# Altium schematic sheet Powersupply.SchDoc(Powersupply)
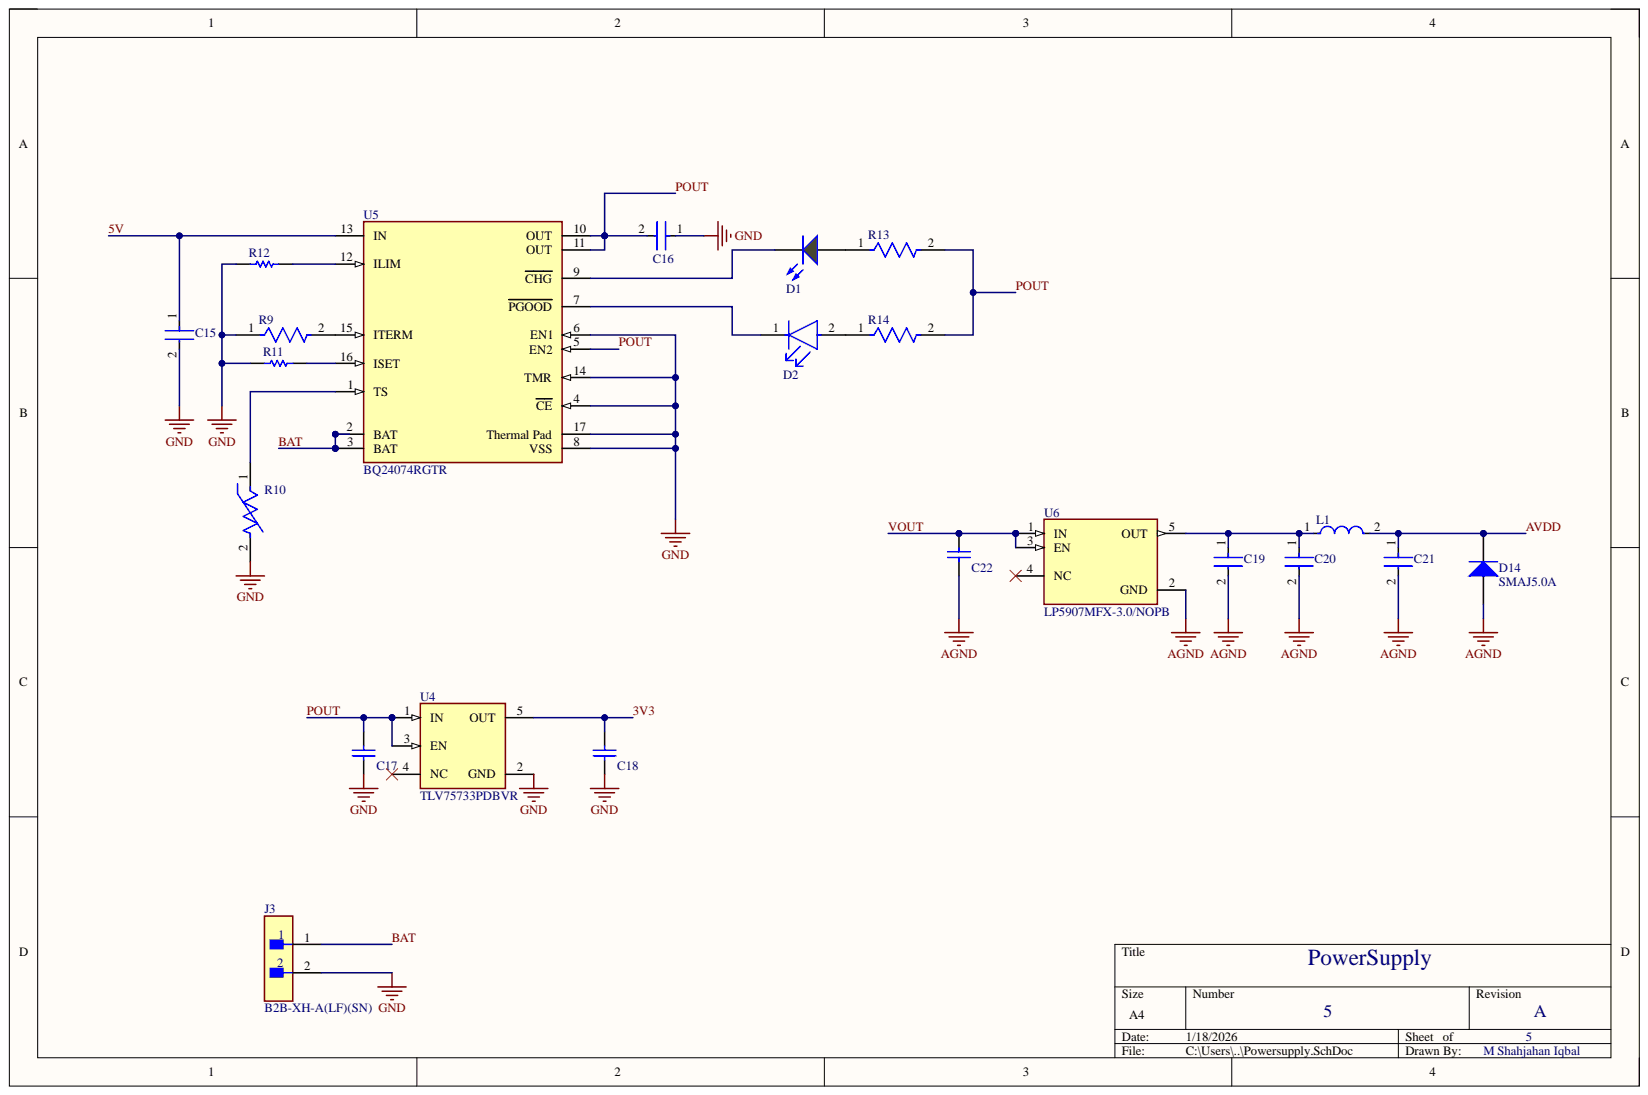
[diagram p0: Powersupply.SchDoc]

## Parts
C15  CL10B105KP8NNNC  [FP-CL10-IPC_C]  (value not drawn)
C16  CL10A475KP8NNNC  [FP-CL10-IPC_C]  (value not drawn)
C17  GRM188R61A105KA61D  [CAPC1608X90X35LL10T15]  (value not drawn)
C18  GRM188R61A105KA61D  [CAPC1608X90X35LL10T15]  (value not drawn)
C19  CC0603KRX7R6BB225  [FP-CC0603-DA-MFG]  (value not drawn)
C20  GRT188R61A106KE13D  [FP-GRT188-0_15-IPC_C]  (value not drawn)
C21  GRT188R61A106KE13D  [FP-GRT188-0_15-IPC_C]  (value not drawn)
C22  GRM188R61A105KA61D  [CAPC1608X90X35LL10T15]  (value not drawn)
D1  APT1608SGC  [KING-APT1608SGC_V]  (value not drawn)
D2  APT1608SYCK  [FP-APT1608SYCK-MFG]  (value not drawn)
D14  SMAJ5.0A  [BOUR-SMA-2_V]
J3  B2B-XH-A(LF)(SN)  [FP-B2B-XH-A_LF_SN-MFG]
L1  LQM21PN3R3MG0D  [FP-LQM21PH_G0-MFG]  (value not drawn)
R9  CRCW06034K12FKEA  [FP-CRCW0603-e3-IPC_B]  (value not drawn)
R10  103AT-2  [FP-103AT-2-MFG]  (value not drawn)
R11  CRCW06031K13FKEA  [RESC1609X50X30ML10T20]  (value not drawn)
R12  CRCW06031K18FKEA  [RESC1609X50X30ML10T20]  (value not drawn)
R13  AF0603FR-071K5L  [FP-AF0603-IPC_B]  (value not drawn)
R14  AF0603FR-071K5L  [FP-AF0603-IPC_B]  (value not drawn)
U4  TLV75733PDBVR  [FP-DBV0005A-MFG]
U5  BQ24074RGTR  [FP-RGT0016C-IPC_B]
U6  LP5907MFX-3.0/NOPB  [SOT95P280X145-5N]

## Nets
AGND: C19.2 C20.2 C21.2 C22.2 D14.1 U6.2(GND)
GND: C15.2 C16.1 C17.2 C18.2 J3.2 R9.1 R10.2 R11.1 R12.1 U4.2(GND) U5.4(CE) U5.6(EN1) U5.8(VSS) U5.14(TMR) U5.17(Thermal_Pad)
3V3: C18.1 U4.5(OUT)
5V: C15.1 U5.13(IN)
AVDD: C21.1 D14.2 L1.2
BAT: J3.1 U5.2(BAT) U5.3(BAT)
POUT: C16.2 C17.1 R13.2 R14.2 U4.1(IN) U4.3(EN) U5.5(EN2) U5.10(OUT) U5.11(OUT)
VOUT: C22.1 U6.1(IN) U6.3(EN)
NetC19_1: C19.1 C20.1 L1.1 U6.5(OUT)
NetD1_1: D1.1 R13.1
NetD1_2: D1.2 U5.9(CHG)
NetD2_1: D2.1 U5.7(PGOOD)
NetD2_2: D2.2 R14.1
NetR9_2: R9.2 U5.15(ITERM)
NetR10_1: R10.1 U5.1(TS)
NetR11_2: R11.2 U5.16(ISET)
NetR12_2: R12.2 U5.12(ILIM)

Not connected: U4 4(NC); U6 4(NC)

## Library data of the parts
C15 = CMP-2000-06094-2: Cap Ceramic 1uF 10V X7R ±10% Pad SMD 0603 +125°C T/R; Capacitance: 1uF; Case Code (Imperial): 0603; Case Code (Metric): 1608; Case/Package: 0603; Component Kind: Standard; Contact Plating: Tin; Depth: 0.8mm; Dielectric: X7R; Height: 0.8mm; Lead Free: Lead Free; Length: 1.6mm; Library Name: Altium Content Vault; Max Operating Temperature: 125°C; Min Operating Temperature: -55°C; Mount: Surface Mount; Package Quantity: 1; Packaging: Tape and Reel; Radiation Hardening: No; Thickness: 0.035inch; Tolerance: 10%; Voltage Rating: 10V; Voltage Rating (DC): 10V; Width: 0.031inch
C16 = CMP-13271-003017-1: Cap Ceramic 4.7uF 10V X5R ±10% Pad SMD 0603 +85°C T/R; Capacitance: 4.7uF; Case Code (Imperial): 0603; Case Code (Metric): 1608; Case/Package: 0603; Component Kind: Standard; Contact Plating: Tin; Depth: 0.8mm; Dielectric: X5R; Height: 0.8mm; Length: 1.6mm; Library Name: Altium Content Vault; Max Operating Temperature: 85°C; Min Operating Temperature: -55°C; Mount: Surface Mount; Package Quantity: 1; Packaging: Tape and Reel; Radiation Hardening: No; Thickness: 0.035inch; Tolerance: 10%; Voltage Rating: 10V; Width: 0.031inch
C17 C18 C22 = CMP-2000-06336-1: Chip Capacitor, 1 uF, +/- 10%, 10 V, -55 to 85 degC, 0603 (1608 Metric), RoHS, Tape and Reel; Manufacturer: Murata; Brand: MurataElectronics; Capacitance: 1uF; Capacitance - nF: 1000nF; Case Height: 0.8mm; Case Length: 1.6mm; Dielectric: X5R; Temperature Coefficient / Code: +/-15%; Type: GeneralPurposeMLCC; Voltage Rating: 10V; Case Code - in: 0603; Case Code - mm: 1608; Case Width: 0.8mm; Component Kind: Standard; Datasheet URL: http://search.murata.co.jp/Ceramy/image/img/A01X/G101/ENG/GRM188R61A105KA61-01.pdf; Datasheet Version: 02/2016; Dimensions: 0.8mmWx1.6mmLx0.8mmH; Factory Pack Quantity: 4000; Library Name: Altium Content Vault; Manufacturer URL: http://www.murata.com/; Maximum Operating Temperature: +85C; Minimum Operating Temperature: -55C; Mounting Technology: SurfaceMount; Operating Temperature Range: -55Cto+85C; Package / Case: 0603(1608metric); Package Description: 2-Pin Surface Mount Device, Body 1.6 x 0.8 mm; Package Reference: 0603; Packaging: Reel; Product: GeneralTypeMLCCs; Product Category: MultilayerCeramicCapacitorsMLCC-SMD/SMT; RoHS: Details; Series: GRM; Termination Style: SMD/SMT; Tolerance: 10%; Voltage Rating DC: 10V
C19 = CMP-03422-000148-1: CAP CER 2.2UF 10V X7R 0603; Manufacturer: YAGEO; Material: Ceramic; Temperature Coefficient: 15%; Tolerance: 0.1; Automotive: No; Capacitance: 2.2 uF; Category: Cap; Component Kind: Standard; ComponentLink2Description: Datasheet; ComponentLink2URL: http://www.yageo.com/documents/recent/UPY-GPHC_X7R_6.3V-to-50V_18.pdf; Device Class L1: Passive Components; Device Class L2: Capacitors; Device Class L3: Ceramic Capacitors; Digikey Description: CAP CER 2.2UF 10V X7R 0603; Footprint Url: http://www.yageo.com/exep/pages/download/literatures/UPY-C_GEN_24.pdf; Height: 0.9mm; Lead Free: Yes; Library Name: Altium Content Vault; Manufacturer Part Number: CC0603KRX7R6BB225; Mouser Description: Multilayer Ceramic Capacitors MLCC - SMD/SMT 2.2uF 10V X7R 10%; Octopart: https://octopart.com/cc0603krx7r6bb225-yageo-20649696; Package: CC0603-DA; RoHS: TRUE; Temperature: -55°C to +125°C; Temperature Characteristic: X7R; Temperature Range High: +125°C; Temperature Range Low: -55°C; Value: 2.2 uF; Voltage: 10 V; Voltage Rating: 10 V
C20 C21 = CMP-06035-045859-1: Multi-Layer Ceramic Capacitor 10uF 10V X5R ±10% 0603 Paper T/R; Capacitance: 10uF; Case Code (Imperial): 0603; Case Code (Metric): 1608; Case/Package: 0603; Component Kind: Standard; Length: 0.063inch; Library Name: Altium Content Vault; Max Operating Temperature: 85°C; Min Operating Temperature: -55°C; Mount: Surface Mount; Packaging: Cut Tape; Ratings: AEC-Q200; Thickness: 0.035inch; Tolerance: 10%; Voltage Rating: 10V; Width: 0.031inch
D1 = CMP-2000-05789-1: Super Bright Green LED, 62.5 mW, 25 mA, -40 to 85 degC, 2-Pin SMD, RoHS, Tape and Reel; Manufacturer: Kingbright; 3D Model: APT1608x.sldprt    APT1608x.pro    APT1608x.igs    APT1608x.stp; Catalog Drawings: APT1608SeriesTop    APT1608SeriesSide; Current - Test: 20mA; Lens Style/Size: RectanglewithFlatTop,1.2mmx0.8mm; Lens Type: Clear; Millicandela Rating: 15mcd; Online Catalog: 0603RectanglewithFlatTopChipLEDs; Other Names: 754-1121-2; Viewing Angle: 120°; Voltage - Forward (Vf) (Typ): 2.2V; Wavelength - Dominant: 568nm; Wavelength - Peak: 565nm; Category: Optoelectronics; Color: Green; Component Kind: Standard; Datasheet URL: https://datasheet.ciiva.com/2113/apt1608sgc-2113808.pdf; Datasheet Version: Rev. 16B, 02/2012; Family: LEDIndication-Discrete; Height: 0.75mm; Library Name: Altium Content Vault; Manufacturer URL: http://www.kingbright.com/; Mounting Technology: SurfaceMount; Mounting Type: SurfaceMount; Package / Case: 0603(1608Metric); Package Description: 2-Pin Surface Mount Device, Body 0.8 x 1.6 mm; Package Reference: APT1608SGC; Packaging: Tape&Reel(TR); Size / Dimension: 1.60mmLx0.80mmW; Standard Package: 2,000
D2 = CMP-44529-000010-1: LED YELLOW CLEAR CHIP SMD; Manufacturer: Kingbright; Forward Current: 20mA; Forward Voltage: 2V; Led Orientation: Top View; Luminous Intensity: 150mcd; Max Junction Temp: +115°C; Peak Emmision Wavelength: 590nm; Power Dissipation: 75mW; Reverse Voltage: 5V; Viewing Angle: 120°; Automotive: No; Category: Diode; Colour: Yellow; Component Kind: Standard; ComponentLink2Description: Datasheet; ComponentLink2URL: http://www.kingbrightusa.com/images/catalog/SPEC/APT1608SYCK.pdf; Device Class L1: Optoelectronics; Device Class L2: LEDs; Device Class L3: unset; Digikey Description: LED YELLOW CLEAR CHIP SMD; Height: 0.85mm; Lead Free: Yes; Library Name: Altium Content Vault; Manufacturer Part Number: APT1608SYCK; Mouser Description: Standard LEDs - SMD YELLOW WATER CLEAR; Octopart: https://octopart.com/apt1608syck-kingbright-868958; Package: APT1608SYCK; RoHS: TRUE; Temperature: -40°C to +85°C; Temperature Range High: +85°C; Temperature Range Low: -40°C; Wavelength: 590nm
D14 = CMP-2000-07090-1: SMAJ Transient Voltage Suppressor Diode Series, 3.5 V, -55 to 150 degC, 2-Pin SMA, RoHS, Tape and Reel; Manufacturer: Bourns; Catalog Drawings: SMAJSeriesFootprintSMAJ,SMBJSeries; Current - Peak Pulse (10/1000µs): 43.5A; EDA / CAD Models: DownloadfromAcceleratedDesigns; Featured Product: SMAJ/SMBJ/SMCJ/SMLJDiscreteTVSDiodes; Online Catalog: SMAJSeries; Other Names: SMAJ5.0ABDKR; Power - Peak Pulse: 400W; Power Line Protection: No; Type: Zener; Unidirectional Channels: 1; Voltage - Breakdown (Min): 6.4V; Voltage - Clamping (Max) @ Ipp: 9.2V; Voltage - Reverse Standoff (Typ): 5V; Applications: GeneralPurpose; Bidirectional Channels: -; Capacitance @ Frequency: -; Category: CircuitProtection; Component Kind: Standard; Datasheet URL: https://datasheet.ciiva.com/25624/0900766b8112213b-25624680.pdf; Datasheet Version: 08/2012; Family: TVS-Diodes; Library Name: Altium Content Vault; Manufacturer URL: http://www.bourns.com/; Mounting Technology: SurfaceMount; Mounting Type: SurfaceMount; Operating Temperature: -55°C~150°C(TJ); Package / Case: DO-214AC,SMA; Package Description: 2-Pin Surface Mount Device, Body 4.315 x 2.605 mm; Package Reference: SMA-2; Packaging: Digi-Reel®; RoHS Information: SMAJSeriesMaterialDeclaration; Series: SMAJ; Standard Package: 1; Supplier Device Package: SMA(DO-214AC)
J3 = CMP-2000-05888-3: CONN HEADER VERT 2POS 2.5MM; Connector Type: Male Pin; Contact Resistance: 20mR; Current Rating: 3A; Insulation Resistance: 1GR; Max Voltage Rating (AC): 250V; Number of Contacts: 2; Wire/Cable Gauge: 22AWG; Color: Natural; Component Kind: Standard; Contact Material: Brass; Contact Plating: Tin; Contact Type: Male Pin; Depth: 5.75mm; Fastening Type: Detent; Housing Color: White; Housing Material: Polyamide; Lead Free: Lead Free; Lead Pitch: 2.5mm; Length: 7.4mm; Library Name: Altium Content Vault; Max Current Rating: 3A; Max Operating Temperature: 85°C; Max Voltage Rating (DC): 250V; Min Operating Temperature: -25°C; Mount: Through Hole; Number of Positions: 2; Number of Rows: 1; Orientation: Straight; Packaging: Bulk; Pitch: 2.5mm; REACH SVHC: No SVHC; Series: XH; Termination: Solder; Voltage Rating: 250V; Voltage Rating (AC): 250V
L1 = CMP-06042-017003-1: Multilayer Type Inductor for Power Lines, 0805 (2012), 3.3μH, 20%, 0.207Ω, 0.8A; Core Material: Ferrite; Current Rating: 800mA; DC Resistance (DCR): 207mR; Inductance: 3.3uH; Self Resonant Frequency: 30MHz; Shielding: Shielded; Test Frequency: 1MHz; Case/Package: 0805; Component Kind: Standard; Height - Seated (Max): 0.039inch; Length: 0.079inch; Library Name: Altium Content Vault; Max Operating Temperature: 125°C; Min Operating Temperature: -55°C; Mount: Surface Mount; Package Quantity: 4000; Packaging: Tape and Reel; RoHS Compliant: Yes; Tolerance: 20%; Width: 0.049inch
R9 = CMP-2000-03403-2: RES Thick Film, 4.12kΩ, 1%, 0.1W, 100ppm/°C, 0603; Max Power Dissipation: 100mW; Resistance: 4.12kR; Voltage Rating: 75V; Case Code (Imperial): 0603; Case Code (Metric): 1608; Case/Package: 0603; Component Kind: Standard; Composition: Thick Film; Contact Plating: Tin; Depth: 0.85mm; Features: Automotive AEC-Q200; Height: 0.45mm; Lead Free: Lead Free; Length: 1.55mm; Library Name: Altium Content Vault; Max Operating Temperature: 155°C; Military Standard: Not; Min Operating Temperature: -55°C; Mount: Surface Mount; Number of Pins: 2; Number of Terminations: 2; Package Quantity: 10; Packaging: Tape and Reel; Power Rating: 100mW; Radiation Hardening: No; Temperature Coefficient: 100ppm/°C; Termination: SMD/SMT; Tolerance: 1%; Voltage Rating (DC): 75V; Weight: 7.1E-05oz; Width: 0.85mm
R10 = CMP-2000-05824-2: Thermistor NTC 10KOhm 1% 2-Pin; Resistance: 10kR; Component Kind: Standard; Height: 2.4mm; Lead Pitch: 2.54mm; Length: 17mm; Library Name: Altium Content Vault; Max Operating Temperature: 110°C; Min Operating Temperature: -50°C; Number of Pins: 2; Packaging: Bulk; RoHS Compliant: Yes; Series: AT; Termination: Radial; Tolerance: 1%; Width: 3.7mm
R11 = CMP-2000-02979-1: Manufacturer: Vishay Dale; Component Kind: Standard; Library Name: Altium Content Vault; Part Number: CRCW06031K13FKEA
R12 = CMP-2000-02981-1: Manufacturer: Vishay Dale; Component Kind: Standard; Library Name: Altium Content Vault; Part Number: CRCW06031K18FKEA
R13 R14 = CMP-03412-043191-1: Anti-Sulfurated Chip Resistors Automotive Grade 1.5kΩ 1% 100ppm/°C 0603; Max Power Dissipation: 100mW; Resistance: 1.5kR; Case/Package: 0603; Component Kind: Standard; Features: Moisture Resistant; Height: 0.022inch; Length: 0.063inch; Library Name: Altium Content Vault; Max Operating Temperature: 155°C; Min Operating Temperature: -55°C; Number of Terminations: 2; Packaging: Tape and Reel; Resistor Type: Thick Film; RoHS Compliant: Yes; Series: AF; Temperature Coefficient: 100ppm/°C; Tolerance: 1%; Width: 0.031inch
U4 = CMP-04917-000028-1: IC REG LINEAR 3.3V 1A SOT23-5; Manufacturer: Texas Instruments; Accuracy Percentage: 1%; Dropout Voltage: 1350mV; Max Junction Temp: +125°C; Max Supply Voltage: 5.5V; Min Supply Voltage: 1.45V; Number Of Outputs: 1; Output Type: Fixed; Standoff Height: 0mm; Automotive: No; Category: IC; Component Kind: Standard; ComponentLink2Description: Datasheet; ComponentLink2URL: http://www.ti.com/lit/ds/symlink/tlv757p.pdf; Device Class L1: Integrated Circuits (ICs); Device Class L2: Power Management ICs; Device Class L3: Voltage Regulators - Linear; Digikey Description: IC REG LINEAR 3.3V 1A SOT23-5; Height: 1.45mm; Ipc Land Pattern Name: SOT95P280X145-5; Lead Free: Yes; Library Name: Altium Content Vault; Manufacturer Part Number: TLV75733PDBVR; Mouser Description: 1-A low-IQ small-size low-dropout (LDO) regulator 5-SOT-23 -40 to 125; Octopart: https://octopart.com/tlv75733pdbvr-texas+instruments-91437073; Output Current: 1A; Output Voltage: 3.3V; Package: DBV0005A; RoHS: TRUE; Temperature: -40°C to +125°C; Temperature Range High: +125°C; Temperature Range Low: -40°C
U5 = CMP-0321-00220-3: IC LI-ION CHARGE MGMT 16-QFN; Battery Chemistry: LITHIUM-ION; Battery Pack Voltage: 4.2V; Fault Protection: Over Voltage; Interface: USB; Max Charge Current: 1.5A; Max Supply Voltage: 10.2V; Min Input Voltage: 4.3V; Min Supply Voltage: 4.35V; Number of Cells: 1; Case/Package: VQFN; Component Kind: Standard; Contact Plating: Gold; Lead Free: Lead Free; Library Name: Altium Content Vault; Max Operating Temperature: 125°C; Min Operating Temperature: -40°C; Number of Pins: 16; Output Current: 1.5A; Output Voltage: 4.2V; Package Quantity: 3000; Packaging: Tape and Reel; Radiation Hardening: No; RoHS Compliant: Yes; Series: -; Weight: 0.000808oz
U6 = LP5907MFX-3.0/NOPB: 250-mA, low-noise, high-PSRR, ultra-low-dropout voltage regulator with low IQ and enable; MF: Texas Instruments; Check_prices: https://www.snapeda.com/parts/LP5907MFX-3.0/NOPB/Texas+Instruments/view-part/?ref=eda; Component Kind: Standard; Library Name: LP5907MFX-3.0_NOPB.IntLib; MP: LP5907MFX-3.0/NOPB; Package: SOT-23-5 Texas Instruments; SnapEDA_Link: https://www.snapeda.com/parts/LP5907MFX-3.0/NOPB/Texas+Instruments/view-part/?ref=snap
